# Altium schematic sheet AURA.SchDoc
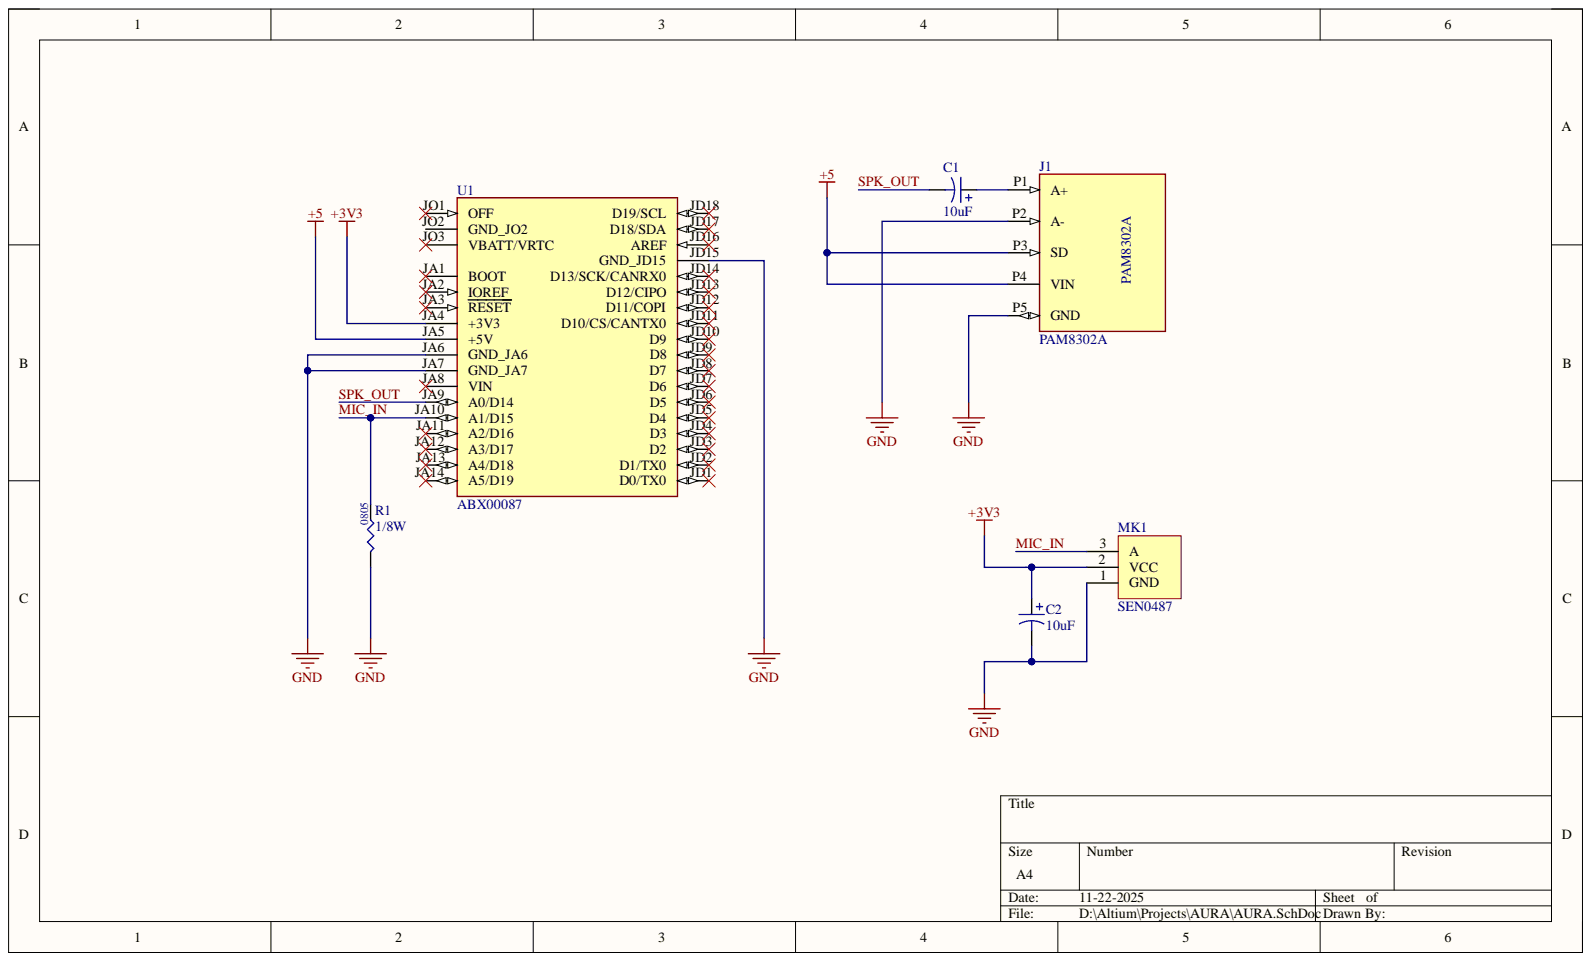
[diagram p0: AURA.SchDoc]

## Parts
C1  value 10uF  Capacitance: 10uF  [865080642006-Footprint-1]  (hidden comment: 865080642006)
C2  value 10uF  Capacitance: 10uF  [865080642006-Footprint-1]  (hidden comment: 865080642006)
J1  PAM8302A  [PAM8302A]
MK1  SEN0487
R1  Package: 0805  Power: 1/8W  [.RES_0805-Footprint-1]  (hidden comment: RC0805FR-0710KL)
U1  ABX00087  [MODULE_ABX00087]

## Nets
+3V3: C2.1 MK1.2 U1.JA4(+3V3)
+5: J1.P3(SD) J1.P4(VIN) U1.JA5(+5V)
GND: C2.2 J1.P2(A-) J1.P5(GND) MK1.1 R1.1 U1.JA6(GND_JA6) U1.JA7(GND_JA7) U1.JD15(GND_JD15)
MIC_IN: MK1.3 R1.2 U1.JA10(A1/D15)
SPK_OUT: C1.2 U1.JA9(A0/D14)
NetC1_1: C1.1 J1.P1(A+)

Not connected: U1 JA1(BOOT) JA2(IOREF) JA3(RESET) JA8(VIN) JA11(A2/D16) JA12(A3/D17) JA13(A4/D18) JA14(A5/D19) JD1(D0/TX0) JD2(D1/TX0) JD3(D2) JD4(D3) JD5(D4) JD6(D5) JD7(D6) JD8(D7) JD9(D8) JD10(D9) JD11(D10/CS/CANTX0) JD12(D11/COPI) JD13(D12/CIPO) JD14(D13/SCK/CANRX0) JD16(AREF) JD17(D18/SDA) JD18(D19/SCL) JO1(OFF) JO2(GND_JO2) JO3(VBATT/VRTC)

## Library data of the parts
C1 C2 = CMP-001-00008-6: 10 µF 50 V Aluminum Electrolytic Capacitors Radial; Voltage: 50V; Component Kind: Standard; Library Name: WARG
J1 = PAM8302A: Audio Amp Speaker 1-CH Mono 2.5W Class-D 8-Pin MSOP T/R; MF: Diodes Zetex; Check_prices: https://www.snapeda.com/parts/PAM8302A/Diodes+Inc./view-part/?ref=eda; Component Kind: Standard; Library Name: PAM8302A.SchLib; MP: PAM8302A; Package: None; SnapEDA_Link: https://www.snapeda.com/parts/PAM8302A/Diodes+Inc./view-part/?ref=snap
R1 = CMP-00421-2: RES 10K OHM 1% 1/8W 0805; Resistance: 10kΩ; Component Kind: Standard; Tolerance: ±1%; Library Name: WARG
U1 = ABX00087: Arduino Uno Rev4 Wifi Rohs Compliant: Yes |Arduino ABX00087; Check_prices: https://www.snapeda.com/parts/ABX00087/Arduino/view-part/?ref=eda; Component Kind: Standard; Library Name: ABX00087.SchLib; MF: Arduino; MP: ABX00087; Package: None; SnapEDA_Link: https://www.snapeda.com/parts/ABX00087/Arduino/view-part/?ref=snap
MK1 = SEN0487: Component Kind: Standard; Library Name: [SEN0487][M027.00640]MIC Module(V1.0).SchLib
